# Altium schematic sheet ESP8266.SchDoc
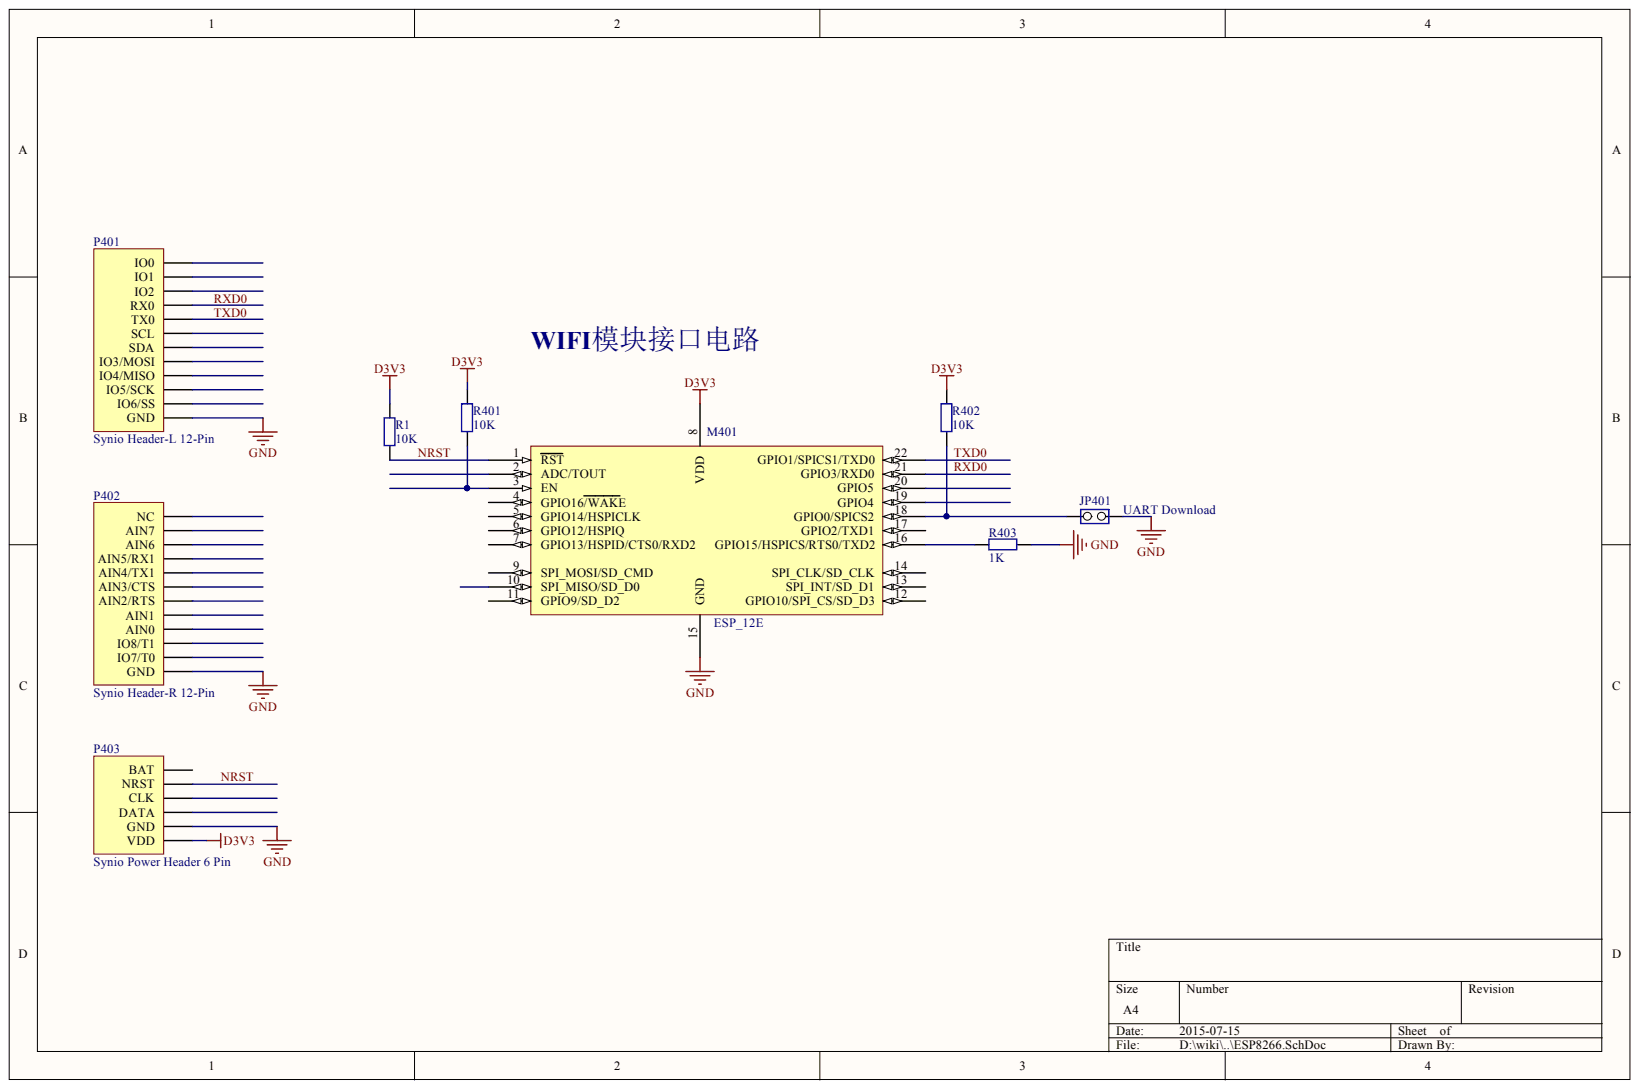
[diagram p0: ESP8266.SchDoc]

## Parts
JP401  UART Download  [SIP2]
M401  ESP_12E  [ESP_12E]
P401  Synio Header-L 12-Pin  [HDR1X12]
P402  Synio Header-R 12-Pin  [HDR1X12]
P403  Synio Power Header 6 Pin  [HDR1X6/2.54MM]
R1  10K  [R0603]
R401  10K  [R0603]
R402  10K  [R0603]
R403  1K  [R0603]

## Nets
D3V3: M401.8(VDD) P403.1(VDD) R1.2 R401.2 R402.1
GND: JP401.1 M401.15(GND) P401.1(GND) P402.1(GND) P403.2(GND) R403.1
NRST: M401.1(RST) P403.5(NRST) R1.1
RXD0: M401.21(GPIO3/RXD0) P401.9(RX0)
TXD0: M401.22(GPIO1/SPICS1/TXD0) P401.8(TX0)
NetJP401_2: JP401.2 M401.18(GPIO0/SPICS2) R402.2
NetM401_3: M401.3(EN) R401.1
NetM401_16: M401.16(GPIO15/HSPICS/RTS0/TXD2) R403.2

Not connected: M401 2(ADC/TOUT) 4(GPIO16/WAKE) 5(GPIO14/HSPICLK) 6(GPIO12/HSPIQ) 7(GPIO13/HSPID/CTS0/RXD2) 9(SPI_MOSI/SD_CMD) 10(SPI_MISO/SD_D0) 11(GPIO9/SD_D2) 12(GPIO10/SPI_CS/SD_D3) 13(SPI_INT/SD_D1) 14(SPI_CLK/SD_CLK) 17(GPIO2/TXD1) 19(GPIO4) 20(GPIO5); P401 2(IO6/SS) 3(IO5/SCK) 4(IO4/MISO) 5(IO3/MOSI) 6(SDA) 7(SCL) 10(IO2) 11(IO1) 12(IO0); P402 2(IO7/T0) 3(IO8/T1) 4(AIN0) 5(AIN1) 6(AIN2/RTS) 7(AIN3/CTS) 8(AIN4/TX1) 9(AIN5/RX1) 10(AIN6) 11(AIN7) 12(NC); P403 3(DATA) 4(CLK) 6(BAT)

## Library data of the parts
JP401 = Jumper2-2: Jumper2; Component Kind: Standard; Library Name: Misc.SchLib
M401 = ESP_12E: ESP-12 Wi-Fi Module by AI-Thinker; IC: ESP8266-EX; Component Kind: Standard; Library Name: Misc.SchLib; Vendor: Ai-thinker
P401 = Synio Header-L 12-Pin: Synio Header-L, 12-Pin; Component Kind: Standard; LatestRevisionDate: 17-Jul-2002; LatestRevisionNote: Re-released for DXP Platform.; Library Name: Connector.SchLib; Signal Integrity: Connector; Publisher: Altium Limited
P402 = Synio Header-R 12-Pin: Synio Header-R, 12-Pin; Component Kind: Standard; LatestRevisionDate: 17-Jul-2002; LatestRevisionNote: Re-released for DXP Platform.; Library Name: Connector.SchLib; Signal Integrity: Connector; Publisher: Altium Limited
R1 R401-R403 = Res2: Resistor; Component Kind: Standard; LatestRevisionDate: 17-Jul-2002; LatestRevisionNote: Re-released for DXP Platform.; Library Name: Miscellaneous Devices.IntLib; PackageReference: AXIAL-0.4; Published: 8-Jun-2000; Signal Integrity: Res; Simulation: RESISTOR; Publisher: Altium Limited
  R1 R401 R402: Value: 10K
  R403: Value: 1K
P403 = Synio Power Header 6 Pin: Component Kind: Standard; Description: Synio Power Header 6 Pin; Library Name: Connector.SchLib
